# Altium schematic sheet PSDT RAM v1.0.0.SchDoc("PSDT RAM v1.0.0")
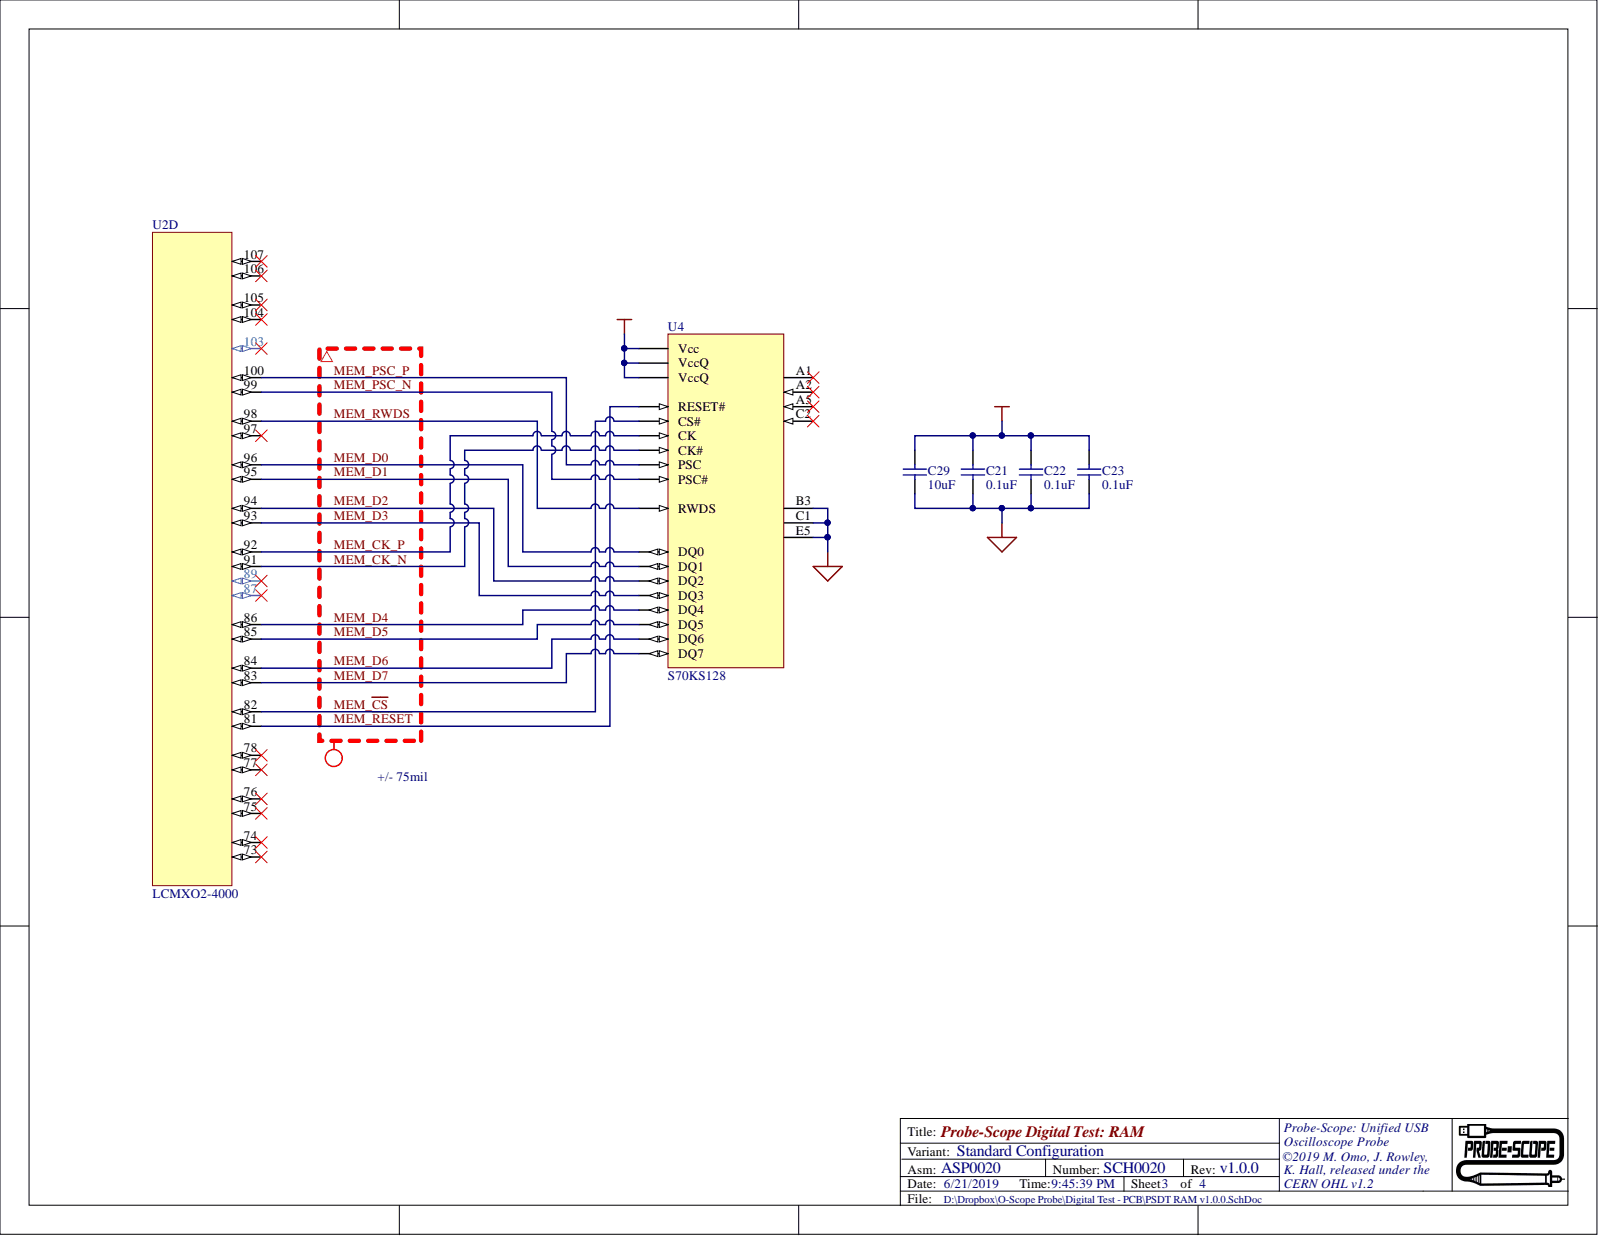
[diagram p0: PSDT RAM v1.0.0.SchDoc]

## Parts
C21  value 0.1uF  [0402(1005)]  (hidden comment: 6.3V)
C22  value 0.1uF  [0402(1005)]  (hidden comment: 6.3V)
C23  value 0.1uF  [0402(1005)]  (hidden comment: 6.3V)
C29  value 10uF  [0603(1608)]  (hidden comment: 25V)
U2  LCMXO2-4000  [UL_TQFP144]  (part D)
U4  S70KS128  [Cypress-VAA024]

## Nets
+1.8V: C21.2 C22.2 C23.2 C29.2 U4.B4(Vcc) U4.D1(VccQ) U4.E4(VccQ)
GND: C21.1 C22.1 C23.1 C29.1 U4.B3 U4.C1 U4.E5
MEM_~{CS}: U2.82 U4.A3(CS#)
MEM_CK_N: U2.91 U4.B1(CK#)
MEM_CK_P: U2.92 U4.B2(CK)
MEM_D0: U2.96 U4.D3(DQ0)
MEM_D1: U2.95 U4.D2(DQ1)
MEM_D2: U2.94 U4.C4(DQ2)
MEM_D3: U2.93 U4.D4(DQ3)
MEM_D4: U2.86 U4.D5(DQ4)
MEM_D5: U2.85 U4.E3(DQ5)
MEM_D6: U2.84 U4.E2(DQ6)
MEM_D7: U2.83 U4.E1(DQ7)
MEM_PSC_N: U2.99 U4.C5(PSC#)
MEM_PSC_P: U2.100 U4.B5(PSC)
MEM_~{RESET}: U2.81 U4.A4(RESET#)
MEM_RWDS: U2.98 U4.C3(RWDS)
N00166:

Not connected: U2 73 74 75 76 77 78 87 89 97 103 104 105 106 107; U4 A1 A2 A5 C2

## Library data of the parts
C21-C23 = 1875: Voltage: 6.3; VENDOR_PN: 1276-1511-1-ND; VENDOR: Digikey; Type: Ceramic; Tolerance: 10; P&V Description: Capacitor, Ceramic, 0.1uF, 6.3V, 10% Tol, 125C, X7R, 0402, SMD; Other: X7R; MENG_PN: ???1875; Max Temperature: 125; MANF_PN: CL05B104KQ5NNNC; MANF: Samsung Electro-Mechanics; Database Table Name: Capacitors; Class: Capacitor; Approved: False; Verified: False; SMD: True; Simulation: CAP; Signal Integrity: Cap; Parent Key: 1875; Package: 0402; Key: 1875; Date Modified: 11/6/2018 11:14:02 AM; Component Kind: Standard; Modified By: jrowley; Library Name: MENG Database Library VPN.DbLib
C29 = 1306: Voltage: 25; VENDOR_PN: 1276-1869-1-ND; VENDOR: Digikey; Type: Ceramic; Tolerance: 20; P&V Description: Capacitor, Ceramic, 10uF, 25V, 20% Tol, 85C, X5R, 0603, SMD; Other: X5R; MENG_PN: ???1306; Max Temperature: 85; MANF_PN: CL10A106MA8NRNC; MANF: Samsung Electro-Mechanics; Database Table Name: Capacitors; Class: Capacitor; Approved: True; Verified: True; SMD: True; Simulation: CAP; Signal Integrity: Cap; Parent Key: 1306; Package: 0603; Key: 1306; Date Modified: 3/3/2015 11:08:29 AM; Component Kind: Standard; Modified By: sotterbacher; Library Name: MENG Database Library VPN.DbLib
U2 = MachXO2-4000-TG144: VENDOR_PN: 220-1460-ND; VENDOR: DigiKey; MANF_PN: LCMXO2-4000HC-4TG144C; MANF: Lattice Semiconductor Corporation; Library Name: PS.SchLib; Component Kind: Standard
U4 = S70KS: VENDOR_PN: 2015-S70KS1281DPBHV020-ND; VENDOR: DigiKey; MANF_PN: S70KS1281DPBHV020; MANF: Cypress; Library Name: PS.SchLib; Component Kind: Standard
